# Altium schematic sheet FPGA.SchDoc(FPGA)
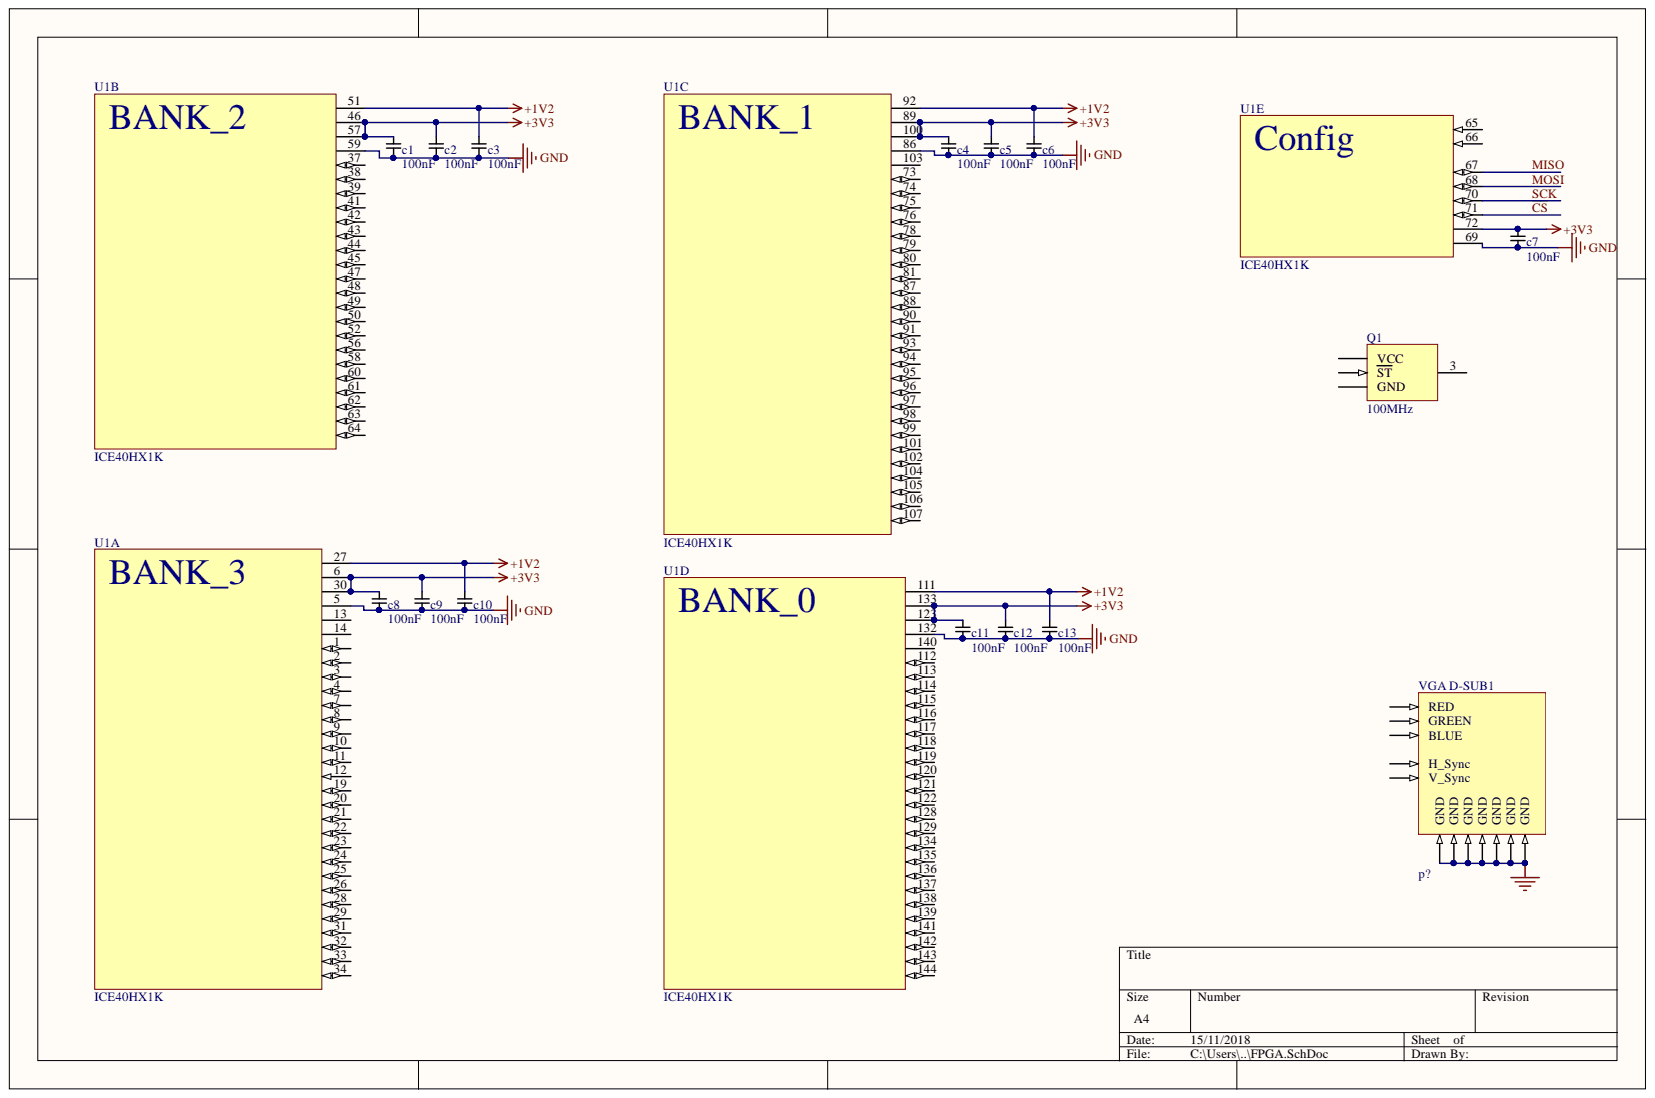
[diagram p0: FPGA.SchDoc]

## Parts
c1  100nF  [0603]
c2  100nF  [0603]
c3  100nF  [0603]
c4  100nF  [0603]
c5  100nF  [0603]
c6  100nF  [0603]
c7  100nF  [0603]
c8  100nF  [0603]
c9  100nF  [0603]
c10  100nF  [0603]
c11  100nF  [0603]
c12  100nF  [0603]
c13  100nF  [0603]
Q1  100MHz  [Crystal Oscillator-2.5X2mm]
U1  ICE40HX1K  [TQFP-144]  (parts A,B,C,D,E)
VGA_D-SUB1  p?  [SUB-D15_VGA]

## Nets
+1V2: c3.2 c6.2 c10.2 c13.2 U1.27 U1.51 U1.92 U1.111
+3V3: c1.2 c2.2 c4.2 c5.2 c7.2 c8.2 c9.2 c11.2 c12.2 U1.6 U1.30 U1.46 U1.57 U1.72 U1.89 U1.100 U1.123 U1.133
GND: c1.1 c2.1 c3.1 c4.1 c5.1 c6.1 c7.1 c8.1 c9.1 c10.1 c11.1 c12.1 c13.1 U1.5 U1.59 U1.69 U1.86 U1.132 VGA_D-SUB1.5(GND) VGA_D-SUB1.6(GND) VGA_D-SUB1.7(GND) VGA_D-SUB1.8(GND) VGA_D-SUB1.10(GND) VGA_D-SUB1.16(GND) VGA_D-SUB1.17(GND)
~{CS}: U1.71
MISO: U1.67
MOSI: U1.68
SCK: U1.70

Not connected: Q1 1(ST) 2(GND) 3 4(VCC); U1 1 2 3 4 7 8 9 10 11 12 13 14 19 20 21 22 23 24 25 26 28 29 31 32 33 34 37 38 39 41 42 43 44 45 47 48 49 50 52 56 58 60 61 62 63 64 65 66 73 74 75 76 78 79 80 81 87 88 90 91 93 94 95 96 97 98 99 101 102 103 104 105 106 107 112 113 114 115 116 117 118 119 120 121 122 128 129 134 135 136 137 138 139 140 141 142 143 144; VGA_D-SUB1 1(RED) 2(GREEN) 3(BLUE) 13(H_Sync) 14(V_Sync)

## Library data of the parts
c1-c13 = 0603 Capacitor: ...F; Library Name: Generic R-C.SchLib; Component Kind: Standard
Q1 = SG-210S*H: Crystal Oscillator; Component Kind: Standard; Library Name: Seiko Epson Corp..SchLib
U1 = ICE40HX1K: ICE40 FPGA TQFP-144; Component Kind: Standard; Library Name: Lattice.SchLib
VGA_D-SUB1 = VGA connector: VGA receptor; Component Kind: Standard; Library Name: EDAC.SchLib
